# Altium schematic sheet [7]-CONNECTORS.SchDoc([7]-CONNECTORS)
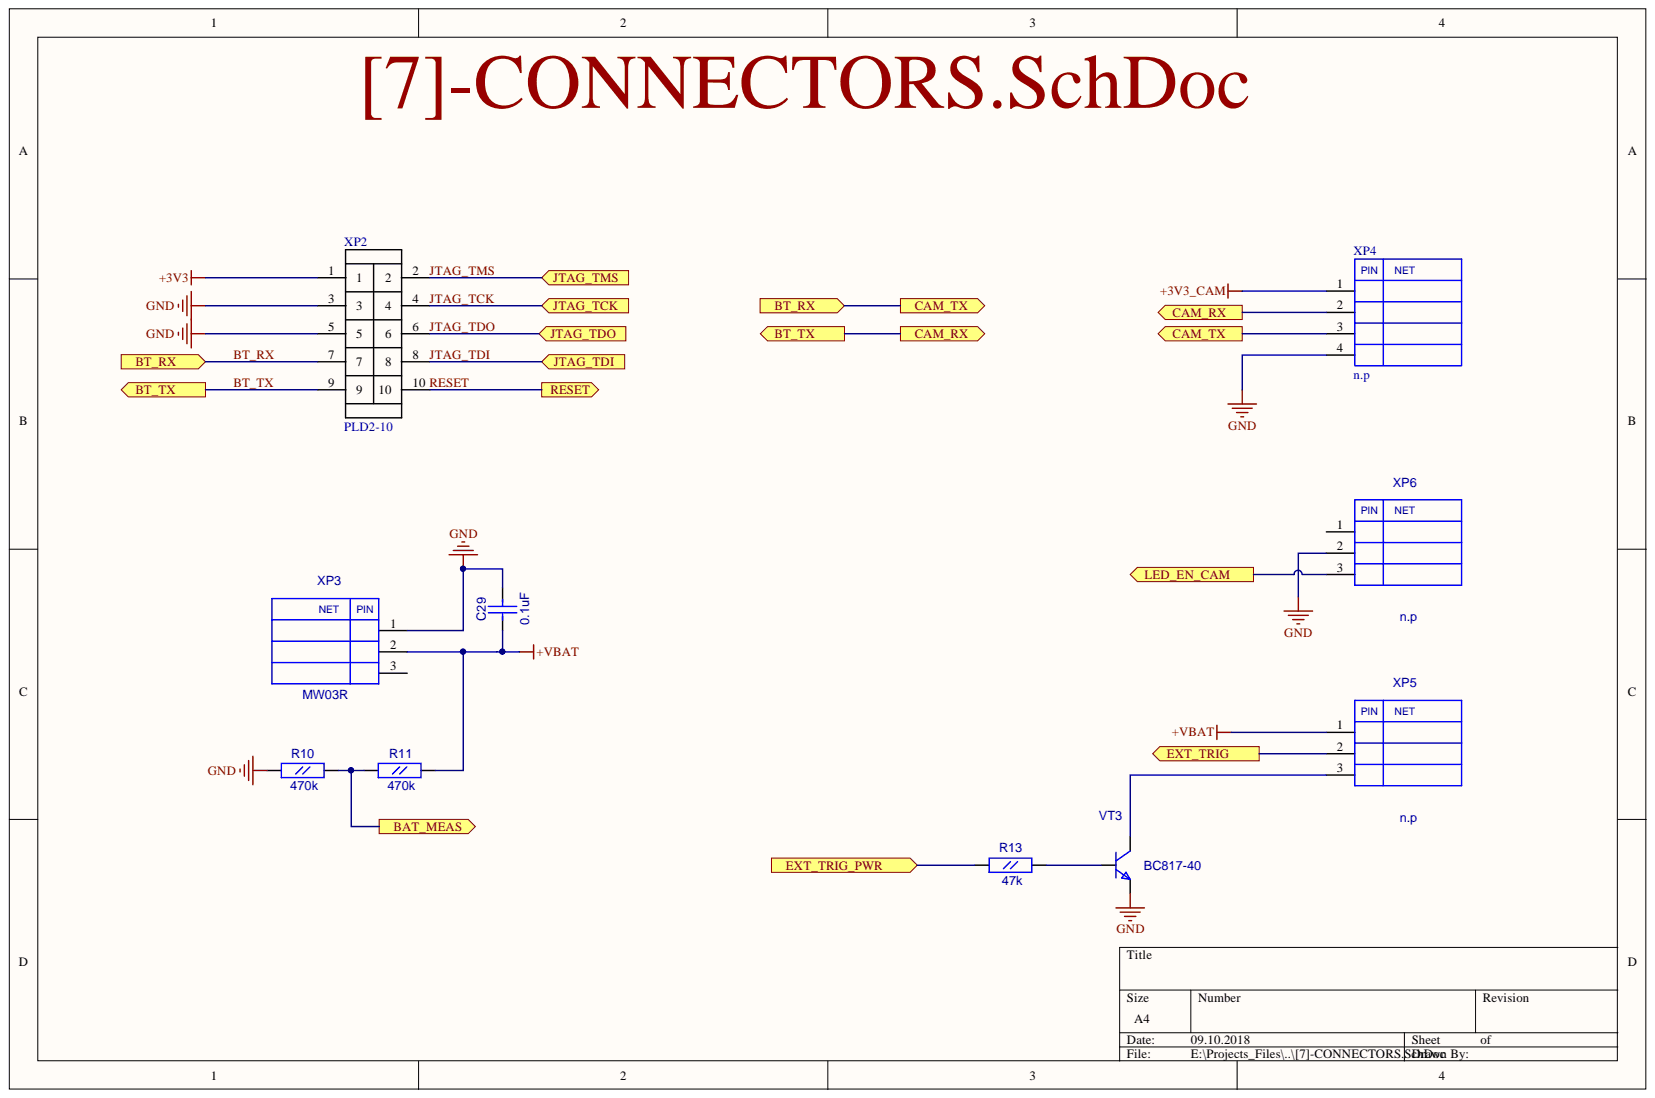
[diagram p0: [7]-CONNECTORS.SchDoc]

## Parts
C29  0.1uF  [CC0603]
R10  470k  [RC0603]
R11  470k  [RC0603]
R13  47k  [RC0603]
VT3  BC817-40  [SOT-23(123)]
XP2  PLD2-10  [PLD2-10]
XP3  MW03R  [MW03R]
XP4  n.p  [PLS2-04]
XP5  n.p  [PLS-03]
XP6  n.p  [PLS2-03]

## Ports
BAT_MEAS, BT_RX, BT_TX, CAM_RX, CAM_TX, EXT_TRIG, EXT_TRIG_PWR, JTAG_TCK, JTAG_TDI, JTAG_TDO, JTAG_TMS, LED_EN_CAM, RESET

## Nets
+3V3: XP2.1
+3V3_CAM: XP4.1
+VBAT: C29.1 R11.2 XP3.2 XP5.1
GND: C29.2 R10.1 VT3.2 XP2.3 XP2.5 XP3.1 XP4.4 XP6.2
BAT_MEAS: R10.2 R11.1 ; port BAT_MEAS
BT_RX: XP2.7 XP4.3 ; port BT_RX, port CAM_TX
BT_TX: XP2.9 XP4.2 ; port BT_TX, port CAM_RX
EXT_TRIG: XP5.2 ; port EXT_TRIG
EXT_TRIG_PWR: R13.1 ; port EXT_TRIG_PWR
JTAG_TCK: XP2.4 ; port JTAG_TCK
JTAG_TDI: XP2.8 ; port JTAG_TDI
JTAG_TDO: XP2.6 ; port JTAG_TDO
JTAG_TMS: XP2.2 ; port JTAG_TMS
LED_EN_CAM: XP6.3 ; port LED_EN_CAM
RESET: XP2.10 ; port RESET
NetR13_2: R13.2 VT3.1
NetVT3_3: VT3.3 XP5.3

Not connected: XP3 3; XP6 1

## Library data of the parts
C29 = CC0603: Value: 0.1uF; Description: CC0603; Component Kind: Standard; Library Name: fizik_lib.SchLib
R10 R11 R13 = RC0603: Description: RC0603; Component Kind: Standard; Library Name: fizik_lib.SchLib
  R10 R11: Value: 470k
  R13: Value: 47k
VT3 = BC846BLT1: NPN 500ma transistor; ComponentField7: Published 29-Sep-2000; Value: BC817-40; Simulation: BC846BLT1; OriginalName: BC846BLT1; Component Kind: Standard; Library Name: fizik_lib.SchLib
XP4 = PLS2-04: Value: n.p; Description: PLS2-04; Component Kind: Standard; Library Name: fizik_lib.SchLib
XP5 = PLS03: Description: PLS03; Component Kind: Standard; Library Name: fizik_lib.SchLib
XP6 = PLS2-03: Value: n.p; Description: PLS2-03; Component Kind: Standard; Library Name: fizik_lib.SchLib
XP2 = PLD2-10: Value: PLD2-10; Component Kind: Standard; Library Name: gprs_modem_2.SchLib
XP3 = MW03R: Description: MW03R; Component Kind: Standard; Library Name: fizik_lib.SchLib
